# Altium schematic sheet WC_Board.SchDoc
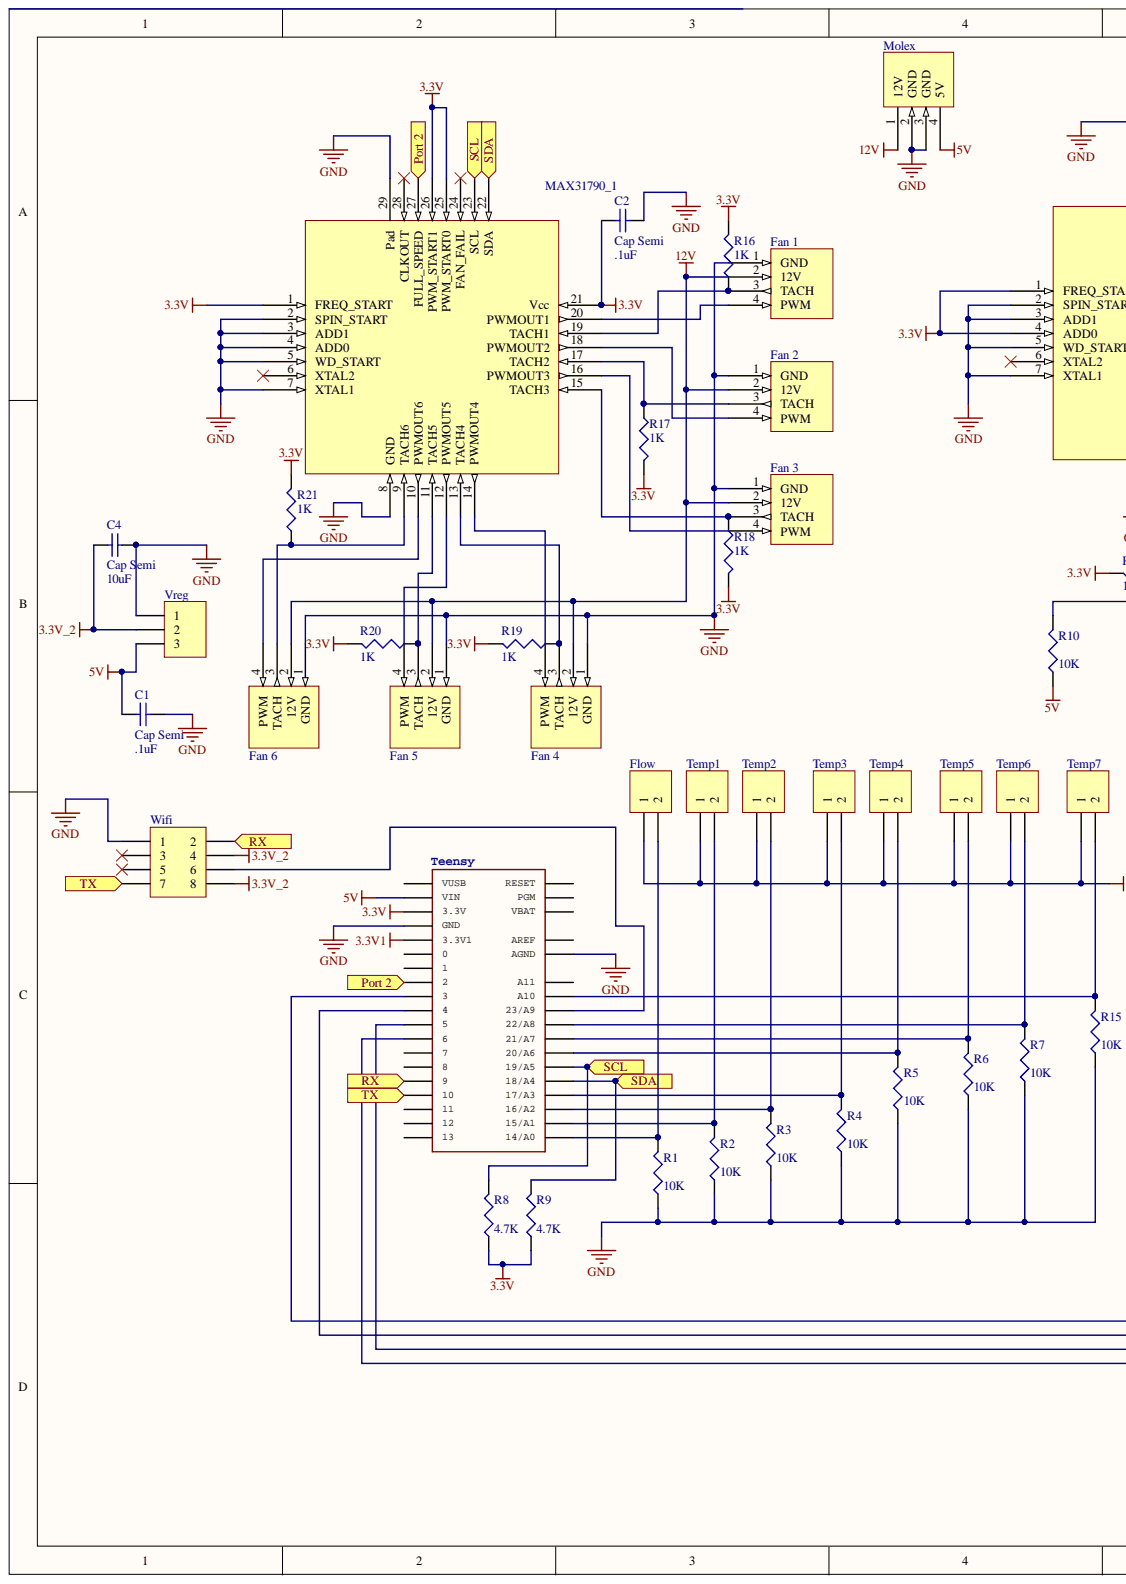
[diagram p0: WC_Board.SchDoc, left]
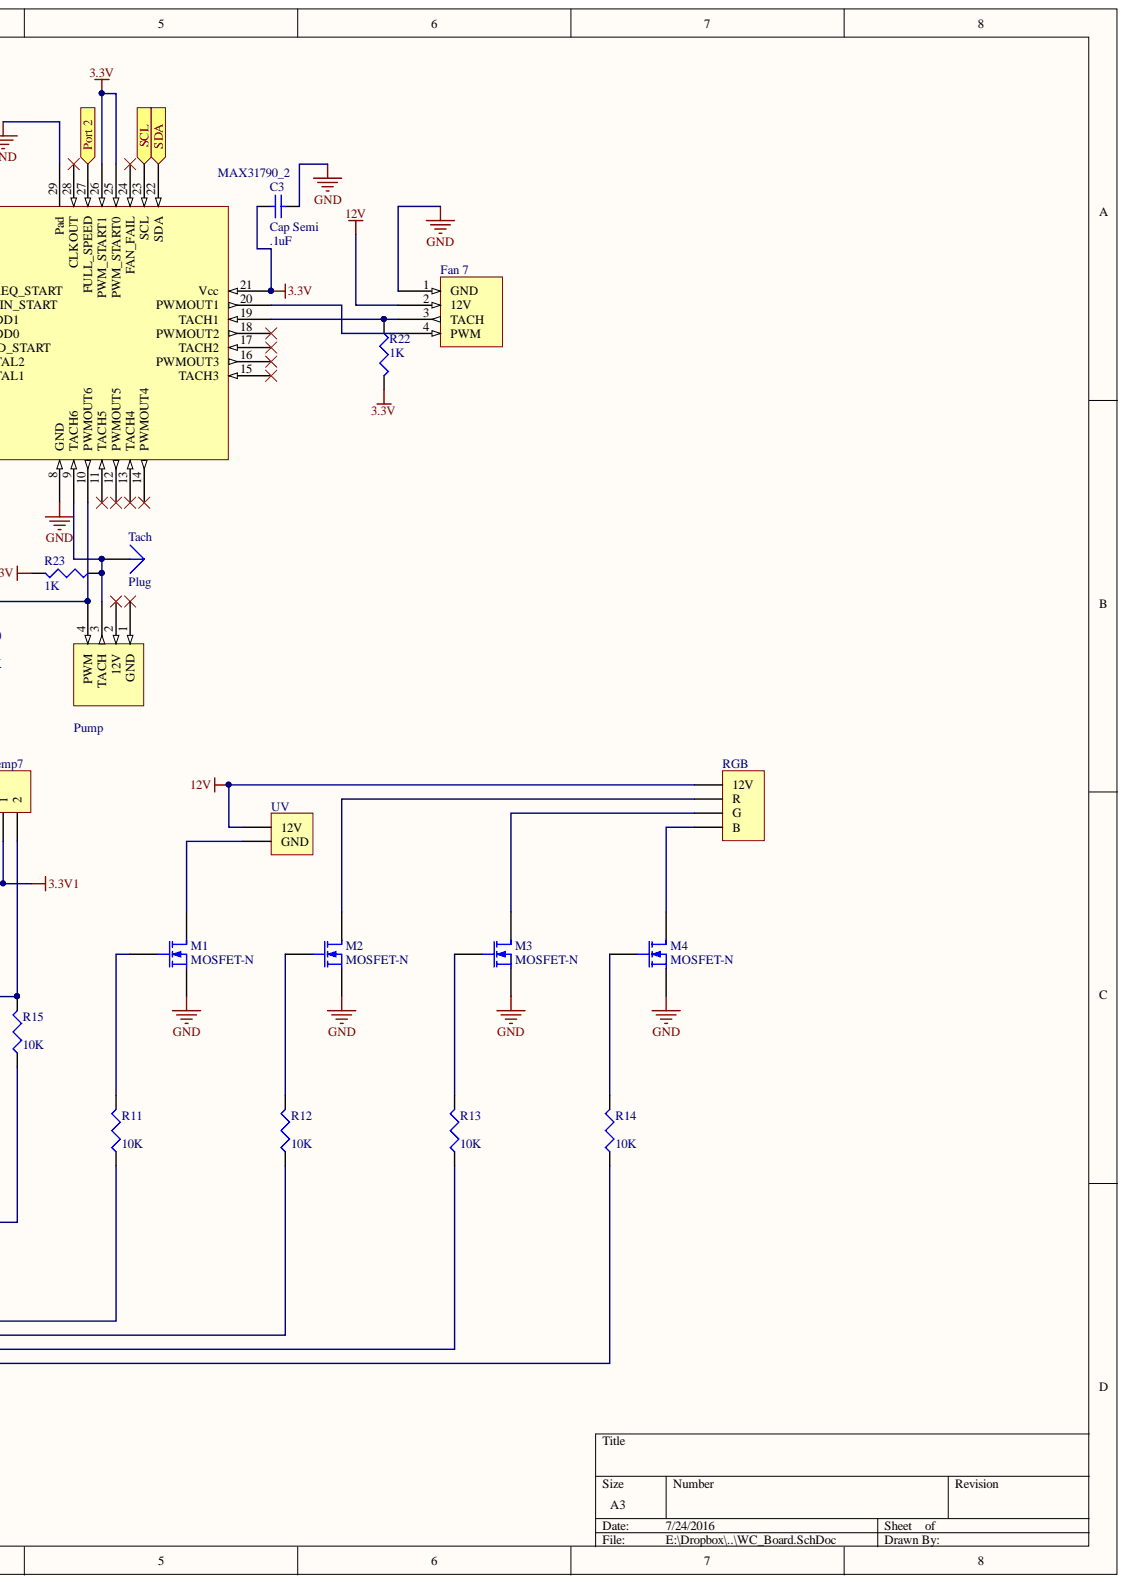
[diagram p1: WC_Board.SchDoc, right]

## Parts
C1  Cap Semi  value .1uF  [C0805]
C2  Cap Semi  value .1uF  [C0805]
C3  Cap Semi  value .1uF  [C0805]
C4  Cap Semi  value 10uF  [C0805]
Fan_1  Fan 1  [Fan Header]
Fan_2  Fan 2  [Fan Header]
Fan_3  Fan 3  [Fan Header]
Fan_4  Fan 4  [Fan Header]
Fan_5  Fan 5  [Fan Header]
Fan_6  Fan 6  [Fan Header]
Fan_7  Fan 7  [Fan Header]
Flow  Flow  [HDR1X2]
M1  MOSFET-N  [TO-220-AB]
M2  MOSFET-N  [TO-220-AB]
M3  MOSFET-N  [TO-220-AB]
M4  MOSFET-N  [TO-220-AB]
MAX31790_1  MAX31790  [QFN40P400X400X80_HS-29N]
MAX31790_2  MAX31790  [QFN40P400X400X80_HS-29N]
Molex  Molex  [Molex]
Pump  Pump  [Fan Header]
R1  value 10K  [6-0805_N]  (hidden comment: Res3)
R2  value 10K  [6-0805_N]  (hidden comment: Res3)
R3  value 10K  [6-0805_N]  (hidden comment: Res3)
R4  value 10K  [6-0805_N]  (hidden comment: Res3)
R5  value 10K  [6-0805_N]  (hidden comment: Res3)
R6  value 10K  [6-0805_N]  (hidden comment: Res3)
R7  value 10K  [6-0805_N]  (hidden comment: Res3)
R8  value 4.7K  [6-0805_N]  (hidden comment: Res3)
R9  value 4.7K  [6-0805_N]  (hidden comment: Res3)
R10  value 10K  [6-0805_N]  (hidden comment: Res3)
R11  value 10K  [6-0805_N]  (hidden comment: Res3)
R12  value 10K  [6-0805_N]  (hidden comment: Res3)
R13  value 10K  [6-0805_N]  (hidden comment: Res3)
R14  value 10K  [6-0805_N]  (hidden comment: Res3)
R15  value 10K  [6-0805_N]  (hidden comment: Res3)
R16  value 1K  [6-0805_N]  (hidden comment: Res3)
R17  value 1K  [6-0805_N]  (hidden comment: Res3)
R18  value 1K  [6-0805_N]  (hidden comment: Res3)
R19  value 1K  [6-0805_N]  (hidden comment: Res3)
R20  value 1K  [6-0805_N]  (hidden comment: Res3)
R21  value 1K  [6-0805_N]  (hidden comment: Res3)
R22  value 1K  [6-0805_N]  (hidden comment: Res3)
R23  value 1K  [6-0805_N]  (hidden comment: Res3)
RGB  RGB  [HDR1X4]
Tach  Plug  [PIN1]
Teensy  Teensy 3.0 board, showing all pins that are easily acessible.    See 'AllAnalogPins" and 'AllPins' packages for additional pin holes, as needed.   The 'Basic' package has fewer pins.  [TEENSY3-ALL_PINS]  (value not drawn)
Temp1  Temp 1  [HDR1X2]
Temp2  Temp 2  [HDR1X2]
Temp3  Temp 3  [HDR1X2]
Temp4  Temp 4  [HDR1X2]
Temp5  Temp 5  [HDR1X2]
Temp6  Temp 6  [HDR1X2]
Temp7  Temp 7  [HDR1X2]
UV  UV  [HDR1X2]
Vreg  Header 3  [HDR1X3]  (value not drawn)
Wifi  Wifi  [HDR2X4]

## Ports
PORT 2, RX, SCL, SDA, TX

## Nets
3.3V: C2.1 C3.1 MAX31790_1.1(FREQ_START) MAX31790_1.21(Vcc) MAX31790_1.25(PWM_START0) MAX31790_1.26(PWM_START1) MAX31790_2.1(FREQ_START) MAX31790_2.4(ADD0) MAX31790_2.21(Vcc) MAX31790_2.25(PWM_START0) MAX31790_2.26(PWM_START1) R8.1 R9.1 R16.2 R17.2 R18.2 R19.2 R20.2 R21.2 R22.2 R23.2 Teensy.3.3V
3.3V1: Flow.1 Teensy.3.3V1 Temp1.1 Temp2.1 Temp3.1 Temp4.1 Temp5.1 Temp6.1 Temp7.1
3.3V_2: C4.1 Vreg.2 Wifi.4 Wifi.8
5V: C1.1 Molex.4(5V) R10.1 Teensy.VIN Vreg.3
12V: Fan_1.2(12V) Fan_2.2(12V) Fan_3.2(12V) Fan_4.2(12V) Fan_5.2(12V) Fan_6.2(12V) Fan_7.2(12V) Molex.1(12V) RGB.1(12V) UV.1
GND: C1.2 C2.2 C3.2 C4.2 Fan_1.1(GND) Fan_2.1(GND) Fan_3.1(GND) Fan_4.1(GND) Fan_5.1(GND) Fan_6.1(GND) Fan_7.1(GND) M1.3 M2.3 M3.3 M4.3 MAX31790_1.2(SPIN_START) MAX31790_1.3(ADD1) MAX31790_1.4(ADD0) MAX31790_1.5(WD_START) MAX31790_1.7(XTAL1) MAX31790_1.8(GND) MAX31790_1.29(Pad) MAX31790_2.2(SPIN_START) MAX31790_2.3(ADD1) MAX31790_2.5(WD_START) MAX31790_2.7(XTAL1) MAX31790_2.8(GND) MAX31790_2.29(Pad) Molex.2(GND) Molex.3(GND) R1.1 R2.1 R3.1 R4.1 R5.1 R6.1 R7.1 R15.1 Teensy.AGND Teensy.GND Vreg.1 Wifi.1
RX: Teensy.9 Wifi.2 ; port RX
SCL: MAX31790_1.23(SCL) MAX31790_2.23(SCL) R8.2 Teensy.19/A5 ; port SCL
SDA: MAX31790_1.22(SDA) MAX31790_2.22(SDA) R9.2 Teensy.18/A4 ; port SDA
TX: Teensy.10 Wifi.7 ; port TX
NetFan 1_3: Fan_1.3(TACH) MAX31790_1.19(TACH1) R16.1
NetFan 1_4: Fan_1.4(PWM) MAX31790_1.20(PWMOUT1)
NetFan 2_3: Fan_2.3(TACH) MAX31790_1.17(TACH2) R17.1
NetFan 2_4: Fan_2.4(PWM) MAX31790_1.18(PWMOUT2)
NetFan 3_3: Fan_3.3(TACH) MAX31790_1.15(TACH3) R18.1
NetFan 3_4: Fan_3.4(PWM) MAX31790_1.16(PWMOUT3)
NetFan 4_3: Fan_4.3(TACH) MAX31790_1.13(TACH4) R19.1
NetFan 4_4: Fan_4.4(PWM) MAX31790_1.14(PWMOUT4)
NetFan 5_3: Fan_5.3(TACH) MAX31790_1.11(TACH5) R20.1
NetFan 5_4: Fan_5.4(PWM) MAX31790_1.12(PWMOUT5)
NetFan 6_3: Fan_6.3(TACH) MAX31790_1.9(TACH6) R21.1
NetFan 6_4: Fan_6.4(PWM) MAX31790_1.10(PWMOUT6)
NetFan 7_3: Fan_7.3(TACH) MAX31790_2.19(TACH1) R22.1
NetFan 7_4: Fan_7.4(PWM) MAX31790_2.20(PWMOUT1)
NetFlow_2: Flow.2 R1.2 Teensy.14/A0
NetM1_1: M1.1 UV.2
NetM1_2: M1.2 R11.2
NetM2_1: M2.1 RGB.2(R)
NetM2_2: M2.2 R12.2
NetM3_1: M3.1 RGB.3(G)
NetM3_2: M3.2 R13.2
NetM4_1: M4.1 RGB.4(B)
NetM4_2: M4.2 R14.2
NetMAX31790_1_27: MAX31790_1.27(FULL_SPEED) MAX31790_2.27(FULL_SPEED) Teensy.2 ; port PORT 2
NetMAX31790_2_9: MAX31790_2.9(TACH6) Pump.3(TACH) R23.1 Tach.1
NetMAX31790_2_10: MAX31790_2.10(PWMOUT6) Pump.4(PWM) R10.2
NetR2_2: R2.2 Teensy.15/A1 Temp1.2
NetR3_2: R3.2 Teensy.16/A2 Temp2.2
NetR4_2: R4.2 Teensy.17/A3 Temp3.2
NetR5_2: R5.2 Teensy.20/A6 Temp4.2
NetR6_2: R6.2 Teensy.21/A7 Temp5.2
NetR7_2: R7.2 Teensy.22/A8 Temp6.2
NetR11_1: R11.1 Teensy.3
NetR12_1: R12.1 Teensy.4
NetR13_1: R13.1 Teensy.5
NetR14_1: R14.1 Teensy.6
NetR15_2: R15.2 Teensy.A10 Temp7.2
NetTeensy_23/A9: Teensy.23/A9 Wifi.6
N00007:

Not connected: MAX31790_1 6(XTAL2) 24(FAN_FAIL) 28(CLKOUT); MAX31790_2 6(XTAL2) 11(TACH5) 12(PWMOUT5) 13(TACH4) 14(PWMOUT4) 15(TACH3) 16(PWMOUT3) 17(TACH2) 18(PWMOUT2) 24(FAN_FAIL) 28(CLKOUT); Pump 1(GND) 2(12V); Teensy 0 1 7 8 11 12 13 A11 AREF PGM RESET/DAC(RESET) VBAT VUSB; Wifi 3 5

## Library data of the parts
C1 C4 = Cap Semi: Capacitor (Semiconductor SIM Model); Component Kind: Standard; LatestRevisionDate: 29-May-2009; LatestRevisionNote: IPC-7351 Footprint Added.; Library Name: Miscellaneous Devices.IntLib; PackageDescription: Chip Capacitor; PackageReference: C1206; Published: 8-Jun-2000; Signal Integrity: Cap Semi; Simulation: CAP; Publisher: Altium Limited
C2 C3 = Cap Semi: Capacitor (Semiconductor SIM Model); Value: .1uF; Component Kind: Standard; LatestRevisionDate: 29-May-2009; LatestRevisionNote: IPC-7351 Footprint Added.; Library Name: Miscellaneous Devices.IntLib; PackageDescription: Chip Capacitor; PackageReference: C1206; Published: 8-Jun-2000; Signal Integrity: Cap Semi; Simulation: CAP; Publisher: Altium Limited
Fan_1-Fan_7 Molex Pump = Component_1: Component Kind: Standard
  Fan_1-Fan_7 Pump: Library Name: Fan_header.SchLib
  Molex: Library Name: Molex.SchLib
Flow Temp1-Temp7 UV = Header 2: Header, 2-Pin; Component Kind: Standard; LatestRevisionDate: 17-Jul-2002; LatestRevisionNote: Re-released for DXP Platform.; Library Name: Miscellaneous Connectors.IntLib; Signal Integrity: Connector; Publisher: Altium Limited
M1-M4 = MOSFET-N: N-Channel MOSFET; Component Kind: Standard; LatestRevisionDate: 17-Jul-2002; LatestRevisionNote: Re-released for DXP Platform.; Library Name: Miscellaneous Devices.IntLib; PackageDescription: TO, Flat Index; 3 In-Line, Axial Leads; Body Dia. 4.6mm; Lead Dia. 0.5 mm (max); PackageReference: E3; PackageVersion: 1995/1996; Published: 8-Jun-2000; Simulation: NMOS; Publisher: Altium Limited
R1-R23 = Res3: Resistor; Component Kind: Standard; LatestRevisionDate: 29-May-2009; LatestRevisionNote: IPC-7351 Footprint Added.; Library Name: Miscellaneous Devices.IntLib; PackageDescription: Chip Resistor; PackageReference: J1-0603; Published: 8-Jun-2000; Signal Integrity: Res; Simulation: RESISTOR; Publisher: Altium Limited
RGB = Header 4: Header, 4-Pin; Component Kind: Standard; LatestRevisionDate: 17-Jul-2002; LatestRevisionNote: Re-released for DXP Platform.; Library Name: Miscellaneous Connectors.IntLib; Signal Integrity: Connector; Publisher: Altium Limited
Teensy = TEENSY_3.0_ALL_PINS: Component Kind: Standard; Library Name: Teensy_3_and_LC_Series_Boards_v1.SchLib
Vreg = Header 3: Header, 3-Pin; Component Kind: Standard; LatestRevisionDate: 17-Jul-2002; LatestRevisionNote: Re-released for DXP Platform.; Library Name: Miscellaneous Connectors.IntLib; Signal Integrity: Connector; Publisher: Altium Limited
Wifi = Header 4X2: Header, 4-Pin, Dual row; Component Kind: Standard; LatestRevisionDate: 17-Jul-2002; LatestRevisionNote: Re-released for DXP Platform.; Library Name: Miscellaneous Connectors.IntLib; Signal Integrity: Connector; Publisher: Altium Limited
MAX31790_1 MAX31790_2 = MAX31790: Component Kind: Standard; Library Name: MAX31790.SchLib
Tach = Plug: Component Kind: Standard; Description: Plug; LatestRevisionDate: 17-Jul-2002; LatestRevisionNote: Re-released for DXP Platform.; Library Name: Miscellaneous Connectors.IntLib; Signal Integrity: Connector; Publisher: Altium Limited
